# Altium schematic sheet MCU_LCD_SD_PROG.SchDoc
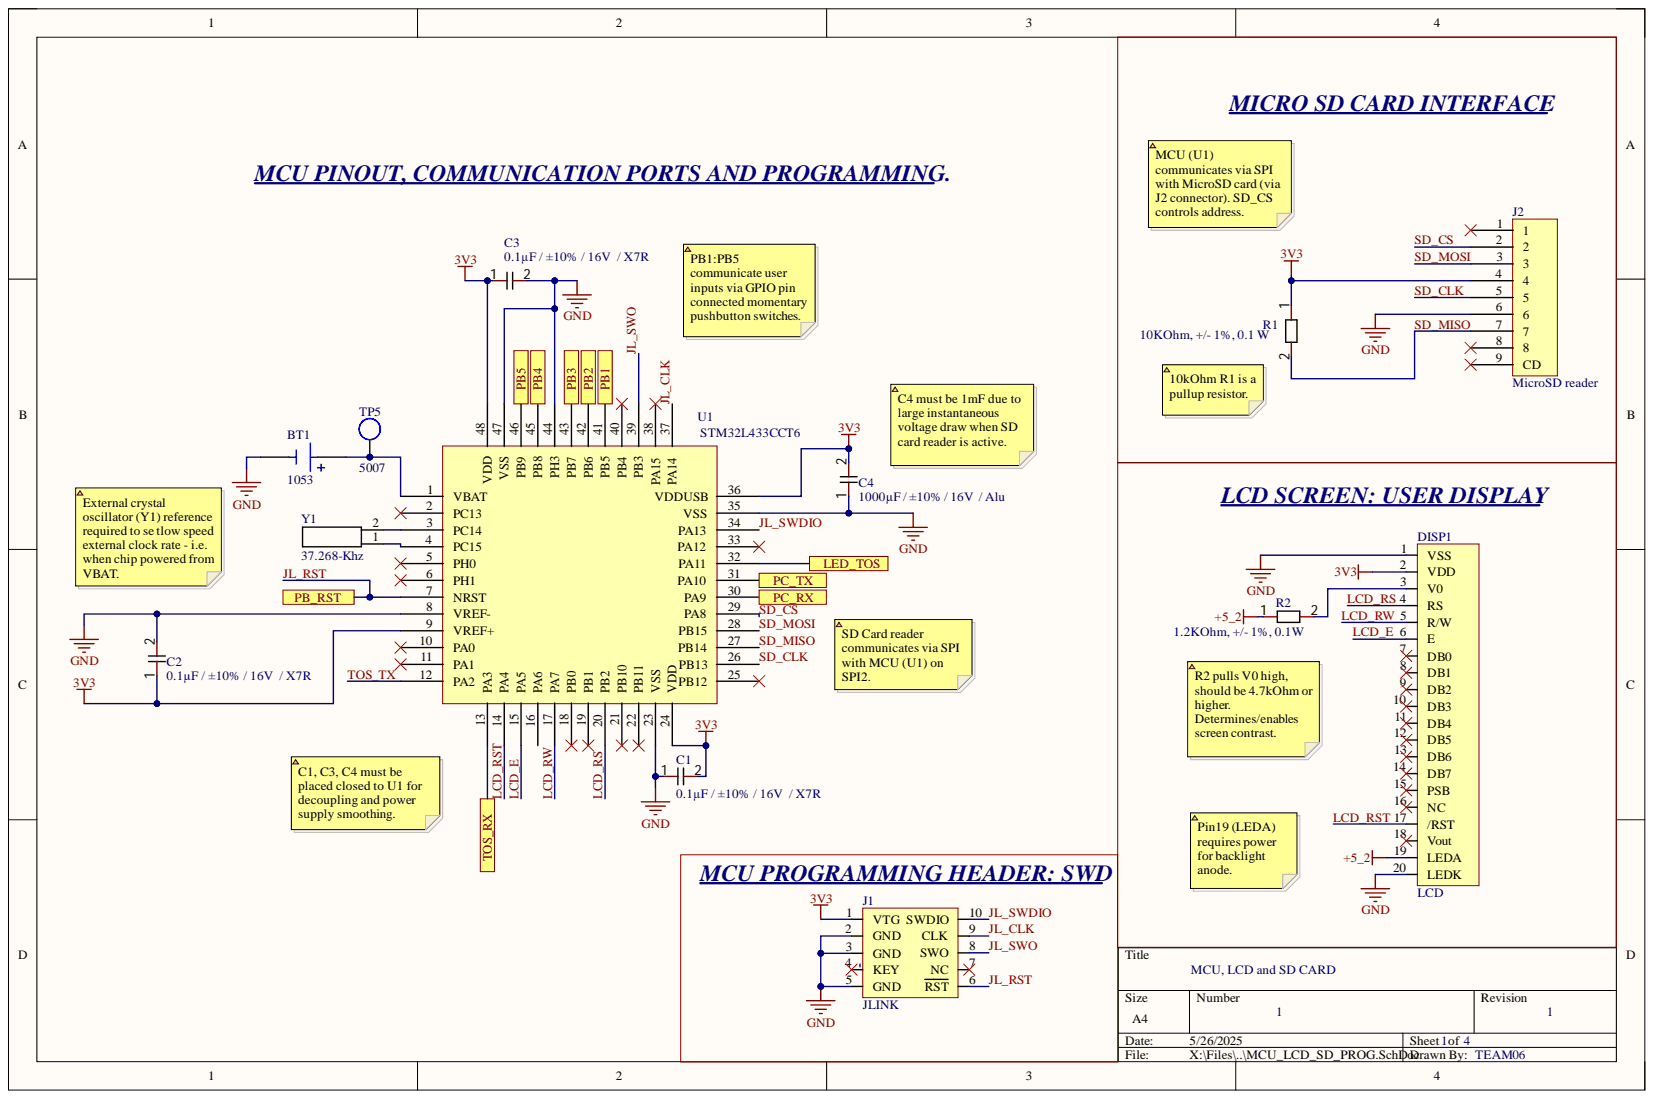
[diagram p0: MCU_LCD_SD_PROG.SchDoc]

## Parts
BT1  1053  [BAT_1053]
C1  0.1µF / ±10% / 16V  / X7R  [SURFM CAPACITOR]
C2  0.1µF / ±10% / 16V  / X7R  [SURFM CAPACITOR]
C3  0.1µF / ±10% / 16V  / X7R  [SURFM CAPACITOR]
C4  1000µF / ±10% / 16V  / Alu  [SURFM CAPACITOR]
DISP1  LCD  [LCD]
J1  JLINK  [J-Link Connector]
J2  MicroSD reader  [SD CARD]
R1  10KOhm, +/- 1%, 0.1 W  [SURFM RESISTOR]
R2  1.2KOhm, +/- 1%, 0.1W  [SURFM RESISTOR]
TP5  5007  [TEST POINT]
U1  STM32L433CCT6  [QFP50P900X900X160-48N]
Y1  37.268-Khz  [CRYSTAL]

## Ports
LED_TOS, PB1, PB2, PB3, PB4, PB5, PB_RST, PC_RX, PC_TX, TOS_RX

## Nets
3V3: C1.2 C2.1 C3.1 C4.2 DISP1.2(VDD) J1.1(VTG) J2.4 R1.1 U1.9(VREF+) U1.24(VDD) U1.36(VDDUSB) U1.48(VDD)
+5_2: DISP1.19(LEDA) R2.1
GND: BT1.N C1.1 C2.2 C3.2 C4.1 DISP1.1(VSS) DISP1.20(LEDK) J1.2(GND) J1.3(GND) J1.5(GND) J2.6 U1.8(VREF-) U1.23(VSS) U1.35(VSS) U1.44(PH3) U1.47(VSS)
JL_CLK: J1.9(CLK) U1.37(PA14)
JL_RST: J1.6(RST) U1.7(NRST) ; port PB_RST
JL_SWDIO: J1.10(SWDIO) U1.34(PA13)
JL_SWO: J1.8(SWO) U1.39(PB3)
LCD_E: DISP1.6(E) U1.15(PA5)
LCD_RS: DISP1.4(RS) U1.20(PB2)
LCD_RST: DISP1.17(/RST) U1.14(PA4)
LCD_RW: DISP1.5(R/W) U1.17(PA7)
LED_TOS: U1.32(PA11) ; port LED_TOS
PB1: U1.41(PB5) ; port PB1
PB2: U1.42(PB6) ; port PB2
PB3: U1.43(PB7) ; port PB3
PB4: U1.45(PB8) ; port PB4
PB5: U1.46(PB9) ; port PB5
PC_RX: U1.30(PA9) ; port PC_RX
PC_TX: U1.31(PA10) ; port PC_TX
SD_CLK: J2.5 U1.26(PB13)
SD_CS: J2.2 U1.29(PA8)
SD_MISO: J2.7 R1.2 U1.27(PB14)
SD_MOSI: J2.3 U1.28(PB15)
TOS_RX: U1.13(PA3) ; port TOS_RX
TOS_TX: U1.12(PA2)
NetBT1_P: BT1.P TP5.1 U1.1(VBAT)
NetDISP1_3: DISP1.3(V0) R2.2
NetU1_3: U1.3(PC14) Y1.2
NetU1_4: U1.4(PC15) Y1.1

Not connected: DISP1 7(DB0) 8(DB1) 9(DB2) 10(DB3) 11(DB4) 12(DB5) 13(DB6) 14(DB7) 15(PSB) 16(NC) 18(Vout); J1 4(KEY) 7(NC); J2 1 8 9(CD); U1 2(PC13) 5(PH0) 6(PH1) 10(PA0) 11(PA1) 16(PA6) 18(PB0) 19(PB1) 21(PB10) 22(PB11) 25(PB12) 33(PA12) 38(PA15) 40(PB4)

## Library data of the parts
BT1 = 1053: Battery Holder, Auto-In/EZ-Out, THM, Coin Cell, 24mm, Plastic, UL94V-0, Black | Keystone Electronics 1053; Check_prices: https://www.snapeda.com/parts/1053/Keystone/view-part/?ref=eda; Component Kind: Standard; Library Name: Control_unit.SchLib; MF: Keystone Electronics; MP: 1053; Package: Package; SnapEDA_Link: https://www.snapeda.com/parts/1053/Keystone/view-part/?ref=snap
C1 = 0805-C: 0.1µF / ±10% / 16V  / X7R  885012207045 0.1 µF ±10% 16V Ceramic Capacitor X7R 0805 (2012 Metric); Component Kind: Standard; Library Name: Control_unit.SchLib
C2 C4 = 0805-C: 885012207045 0.1 µF ±10% 16V Ceramic Capacitor X7R 0805 (2012 Metric); Component Kind: Standard; Library Name: Control_unit.SchLib
C3 = 0805-C: 0.1µF / ±10% / 16V  / X7R   885012207045 0.1 µF ±10% 16V Ceramic Capacitor X7R 0805 (2012 Metric); Component Kind: Standard; Library Name: Control_unit.SchLib
DISP1 = FIT0021: Disply, Lcd, 128X64, Paral/serial Arduino Comp, Blu Bk, 5V, ST7920; Manufacturer: DFRobot; Component Kind: Standard; Library Name: Control_unit.SchLib; Manufacturer Part Number: FIT0021; Pricing 1: 1=18 (USD); Supplier 1: Digikey; Supplier Part Number 1: 1738-FIT0021-ND
J1 = JLINK: Conn Shrouded Header (4 Sides) HDR 10 POS 1.27mm Solder ST Top Entry Thru-Hole; Manufacturer: CNC Tech; Manufacturer Part Number: 3220-10-0100-00; Current Rating: 1A; Number of Contacts: 10NUMBER; Number of Positions: 10; Category: Headers and Wire Housings; Component Kind: Standard; ComponentLink1Description: https://datasheet.ciiva.com/18655/3220-xx-0100-00-18655342.pdf?src-supplier=Digikey; ComponentLink1URL: https://datasheet.ciiva.com/18655/3220-xx-0100-00-18655342.pdf?src-supplier=Digikey; Connector Type: Header; Contact Finish - Mating: GOLD; Contact Material: Brass; Contact Shape: Square; Contact Type: Male Pin; Fastening Type: Pull; Flammability Rating: UL94 V-0; Height: 5.6mm; Insulation Material: Nylon; Library Name: Control_unit.SchLib; Max Operating Temperature: 105°C; Min Operating Temperature: -40°C; Mount: Through Hole; Number of Rows: 2; Packaging: Tray; Pricing 1: 1=0.64, 10=0.54, 100=0.4586, 500=0.40928, 3200=0.35902, 6400=0.34189, 12800=0.32558 (USD); RoHS: Yes; RoHS Compliant: Yes; Series: -; Stock 1: 18235; Supplier 1: Digikey; Supplier Part Number 1: 1175-1627-ND; Termination: Solder
R1 R2 = 0805-R: Chip Resistor, 4.7 KOhm, +/- 1%, 0.1 W, -55 to 155 degC, 0603 (1608 Metric), RoHS, Tape and Reel RC0603FR-074K7L; Component Kind: Standard; Library Name: Control_unit.SchLib
TP5 = 5007: MS27478 - Connectors Receptacle Electrical Solder Mounting Solder Type Hermetic Seal 38999 Series II; Manufacturer: Keystone Electronics; Body Material: Bronze,PBT; Hole Diameter: 1.6mm; Inside Diameter: 1.78mm; Insulation: Insulated; Outside Diameter: 3.18mm; Plating: Silver; Category: Connectors; Color: White; Component Kind: Standard; ComponentLink1Description: https://datasheet.ciiva.com/2917/m60-2p60-2917638.pdf?src-supplier=Digikey; ComponentLink1URL: https://datasheet.ciiva.com/2917/m60-2p60-2917638.pdf?src-supplier=Digikey; ComponentLink2Description: https://datasheet.ciiva.com/22631/1703983-22631109.pdf?src-supplier=Element14; ComponentLink2URL: https://datasheet.ciiva.com/22631/1703983-22631109.pdf?src-supplier=Element14; ComponentLink3Description: https://datasheet.ciiva.com/16884/70182825-16884255.pdf?src-supplier=Allied+Electronics; ComponentLink3URL: https://datasheet.ciiva.com/16884/70182825-16884255.pdf?src-supplier=Allied+Electronics; Contact Material: Bronze; Contact Plating: Tin,Silver; Flammability Rating: UL94 V-0; Height: 0.35inch; Insulation Material: Nylon; Lead Free: Lead Free; Length: 8.89mm; Library Name: Control_unit.SchLib; Manufacturer Part Number: 5007; Material: Nylon,Bronze; Mount: PCB,Through Hole; Package Quantity: 100; Packaging: Bulk; Pricing 1: 1=0.39, 10=0.293, 25=0.2624, 100=0.2223, 500=0.18446, 1000=0.1739, 2500=0.1542, 5000=0.14312 (USD); Reach SVHC Compliant: No; RoHS: Yes; RoHS Compliant: Yes; Series: THM; Stock 1: 45397; Supplier 1: Digikey; Supplier Part Number 1: 36-5007-ND
U1 = STM32L433CCT6: MCU 32-bit Ultra Low Power STM32L ARM Cortex-M4 RISC 256kB Flash 48-Pin LQFP ECOPACK2 Tray; Manufacturer: STMicroelectronics; Data Bus Width: 32b; Interface: I2C,SPI,USB,IrDA,MMC,SD,UART,USART; Max Supply Voltage: 3.6V; Min Supply Voltage: 1.55V; Nominal Supply Current: 10nA; Number of A/D Converters: 1NUMBER; Number of ADC Channels: 10NUMBER; Number of D/A Converters: 2NUMBER; Number of I2C Channels: 3NUMBER; Number of I/Os: 38; Number of PWM Channels: 14NUMBER; Number of UART Channels: 4NUMBER; Oscillator Type: Internal; Peripherals: Brown-out Detect/Reset,PWM,WDT,DMA,LCD; RAM Size: 64KB; Case/Package: LQFP; Category: Microcontrollers; Component Kind: Standard; ComponentLink1Description: http://www.farnell.com/datasheets/2283392.pdf?src-supplier=Farnell; ComponentLink1URL: http://www.farnell.com/datasheets/2283392.pdf?src-supplier=Farnell; ComponentLink2Description: http://www.st.com/content/ccc/resource/technical/document/datasheet/f7/a0/fc/27/24/4e/4f/3f/DM00257192.pdf/files/DM00257192.pdf/jcr:content/translations/en.DM00257192.pdf?src-supplier=Digikey; ComponentLink2URL: http://www.st.com/content/ccc/resource/technical/document/datasheet/f7/a0/fc/27/24/4e/4f/3f/DM00257192.pdf/files/DM00257192.pdf/jcr:content/translations/en.DM00257192.pdf?src-supplier=Digikey; ComponentLink3Description: http://www.farnell.com/datasheets/2283392.pdf?src-supplier=Element14; ComponentLink3URL: http://www.farnell.com/datasheets/2283392.pdf?src-supplier=Element14; ComponentLink4Description: https://datasheet.octopart.com/STM32L433CCT6-STMicroelectronics-datasheet-62336854.pdf?src-supplier=Future+Electronics; ComponentLink4URL: https://datasheet.octopart.com/STM32L433CCT6-STMicroelectronics-datasheet-62336854.pdf?src-supplier=Future+Electronics; ComponentLink5Description: http://www.farnell.com/datasheets/2283392.pdf?src-supplier=Newark; ComponentLink5URL: http://www.farnell.com/datasheets/2283392.pdf?src-supplier=Newark; ComponentLink6Description: https://datasheet.octopart.com/STM32L433CCT6-STMicroelectronics-datasheet-82526589.pdf?src-supplier=SHENGYU+ELECTRONICS; ComponentLink6URL: https://datasheet.octopart.com/STM32L433CCT6-STMicroelectronics-datasheet-82526589.pdf?src-supplier=SHENGYU+ELECTRONICS; Core Architecture: ARM; Density: 256KB; Height: 1.6mm; Library Name: Control_unit.SchLib; Manufacturer Part Number: STM32L433CCT6; Max Operating Temperature: 85°C; Memory Size: 256KB; Memory Type: FLASH; Min Operating Temperature: -40°C; Number of SPI Channels: 3NUMBER; Number of Timers/Counters: 1NUMBER; Number of USB Channels: 1NUMBER; Packaging: Tray; Pricing 1: 1=4.14, 10=3.155, 25=2.91, 100=2.6563 (USD); RoHS: No; RoHS Compliant: Yes; Series: STM32L4; Stock 1: 11512; Supplier 1: Digikey; Supplier Part Number 1: 497-16579-ND
Y1 = CRYSTAL: Component Kind: Standard; Library Name: Control_unit.SchLib
J2 = MicroSD reader: Component Kind: Standard; Library Name: Control_unit.SchLib
